# Altium schematic sheet Holiday Aux r1.SchDoc("Holiday Aux r1")
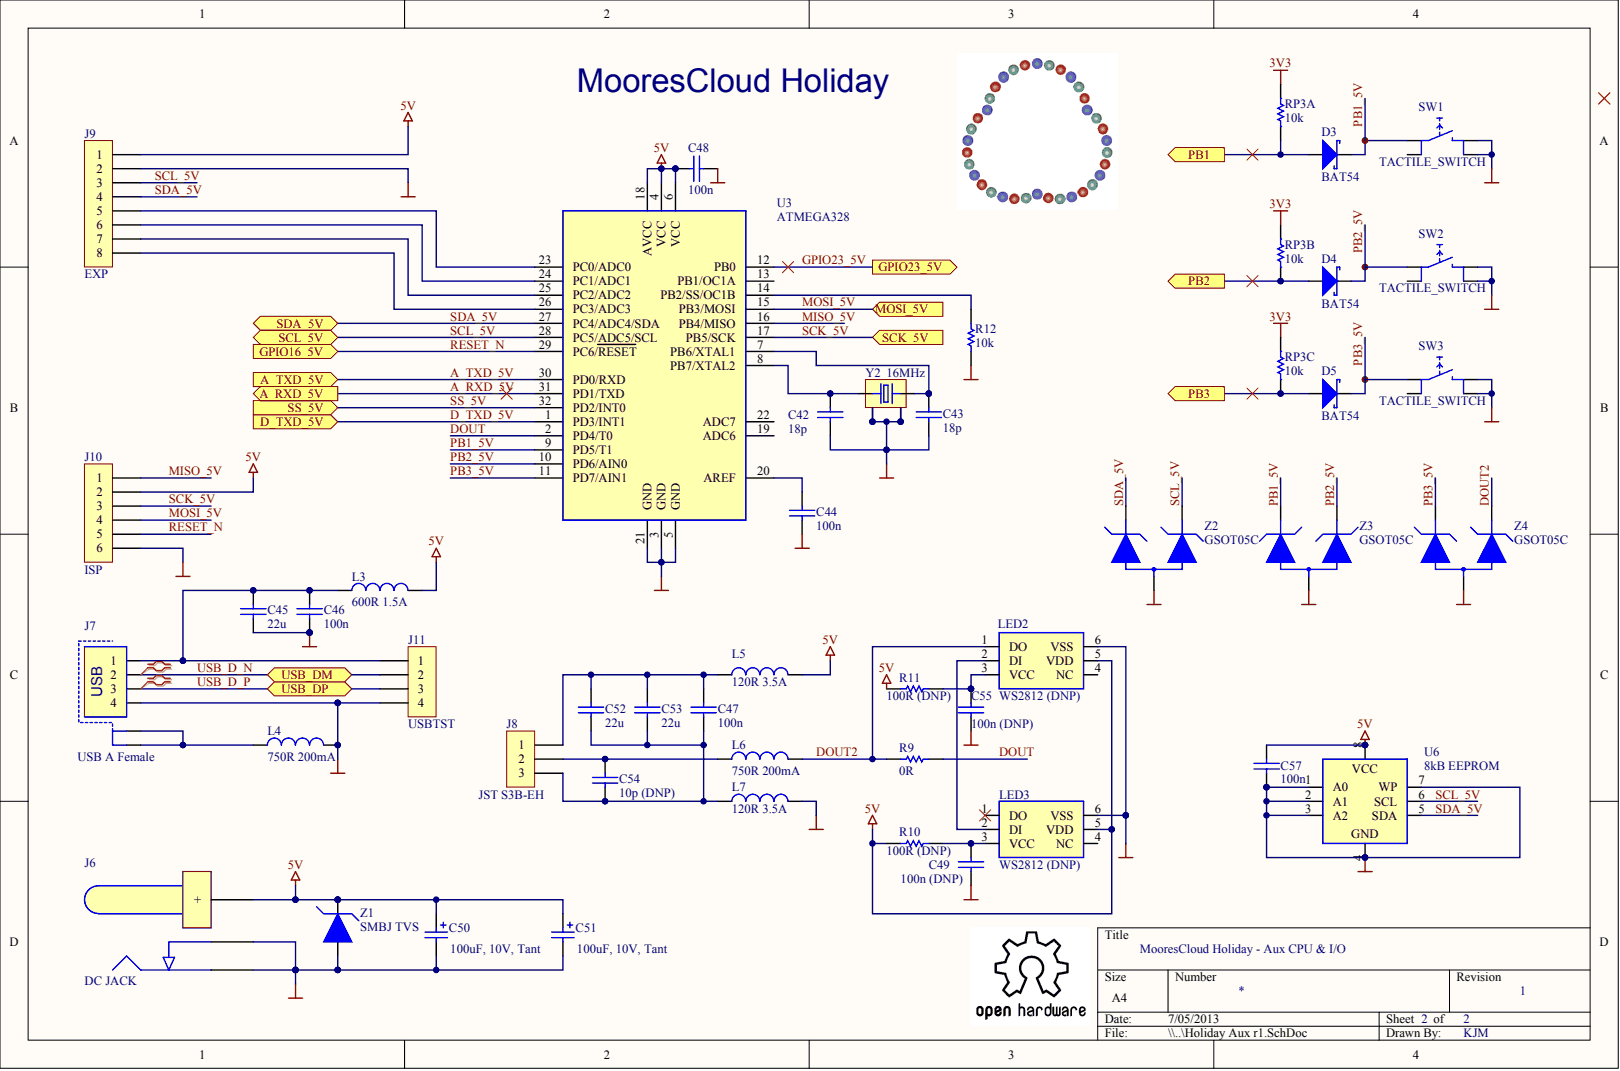
[diagram p0: Holiday Aux r1.SchDoc]

## Parts
C42  18p
C43  18p
C44  100n
C45  22u
C46  100n
C47  100n
C48  100n
C49  100n (DNP)
C50  100uF, 10V, Tant
C51  100uF, 10V, Tant
C52  22u
C53  22u
C54  10p (DNP)
C55  100n (DNP)
C57  100n
D3  BAT54
D4  BAT54
D5  BAT54
J6  DC JACK
J7  USB A Female
J8  JST S3B-EH  1.Manufacturer: JST
J9  EXP
J10  ISP
J11  USBTST
L3  600R 1.5A
L4  750R 200mA
L5  120R 3.5A
L6  750R 200mA
L7  120R 3.5A
LED2  WS2812 (DNP)  2.MfgNo: WS2812
LED3  WS2812 (DNP)  2.MfgNo: WS2812
R9  0R
R10  100R (DNP)
R11  100R (DNP)
R12  10k
RP3  10k  (parts A,B,C)
SW1  TACTILE_SWITCH
SW2  TACTILE_SWITCH
SW3  TACTILE_SWITCH
U3  ATMEGA328
U6  8kB EEPROM
Y2  16MHz
Z1  SMBJ TVS
Z2  GSOT05C
Z3  GSOT05C
Z4  GSOT05C

## Ports
A_RXD_5V, A_TXD_5V, D_TXD_5V, GPIO16_5V, GPIO23_5V, MOSI_5V, PB1, PB2, PB3, SCK_5V, SCL_5V, SDA_5V, SS_5V, USB_DM, USB_DP

## Nets
3V3: RP3.1.2 RP3.2.2 RP3.3.2
5V: C48.1 C50.1 C51.1 C57.1 J6.1 J9.1 J10.2 L3.1 L5.1 LED2.5(VDD) LED3.5(VDD) R10.2 R11.2 U3.4(VCC) U3.6(VCC) U3.18(AVCC) U6.8 Z1.2
GND: C42.2 C43.2 C44.2 C45.2 C46.2 C48.2 C49.1 C50.2 C51.2 C55.1 C57.2 J6.2 J6.3 J7.4 J9.2 J10.6 J11.4 L4.1 L7.1 LED2.6(VSS) LED3.6(VSS) R12.1 SW1.3 SW1.4 SW2.3 SW2.4 SW3.3 SW3.4 U3.3(GND) U3.5(GND) U3.21(GND) U6.1(A0) U6.2(A1) U6.3(A2) U6.4 U6.7(WP) Y2.3 Y2.4 Z1.1 Z2.3 Z3.3 Z4.3
A_RXD_5V: U3.31(PD1/TXD) ; port A_RXD_5V
A_TXD_5V: U3.30(PD0/RXD) ; port A_TXD_5V
D_TXD_5V: U3.1(PD3/INT1) ; port D_TXD_5V
DOUT: R9.2 U3.2(PD4/T0)
DOUT2: L6.1 LED2.1(DO) R9.1 Z4.2
GPIO23_5V: U3.12(PB0) ; port GPIO23_5V
MISO_5V: J10.1 U3.16(PB4/MISO)
MOSI_5V: J10.4 U3.15(PB3/MOSI) ; port MOSI_5V
PB1: D3.1 RP3.1.1 ; port PB1
PB1_5V: D3.2 SW1.1 U3.9(PD5/T1) Z3.2
PB2: D4.1 RP3.2.1 ; port PB2
PB2_5V: D4.2 SW2.1 U3.10(PD6/AIN0) Z3.1
PB3: D5.1 RP3.3.1 ; port PB3
PB3_5V: D5.2 SW3.1 U3.11(PD7/AIN1) Z4.1
RESET_N: J10.5 U3.29(PC6/RESET) ; port GPIO16_5V
SCK_5V: J10.3 U3.17(PB5/SCK) ; port SCK_5V
SCL_5V: J9.3 U3.28(PC5/ADC5/SCL) U6.6(SCL) Z2.2 ; port SCL_5V
SDA_5V: J9.4 U3.27(PC4/ADC4/SDA) U6.5(SDA) Z2.1 ; port SDA_5V
SS_5V: U3.32(PD2/INT0) ; port SS_5V
USB_D_N: J7.2 J11.2 ; port USB_DM
USB_D_P: J7.3 J11.3 ; port USB_DP
NetC42_1: C42.1 U3.8(PB7/XTAL2) Y2.1
NetC43_1: C43.1 U3.7(PB6/XTAL1) Y2.2
NetC44_1: C44.1 U3.20(AREF)
NetC45_1: C45.1 C46.1 J7.1 J11.1 L3.2
NetC47_1: C47.1 C52.2 C53.2 C54.1 J8.3 L7.2
NetC47_2: C47.2 C52.1 C53.1 J8.1 L5.2
NetC49_2: C49.2 LED3.3(VCC) R10.1
NetC54_2: C54.2 J8.2 L6.2
NetC55_2: C55.2 LED2.3(VCC) R11.1
NetJ7_G1: J7.G1 J7.G2 L4.2
NetJ9_5: J9.5 U3.23(PC0/ADC0)
NetJ9_6: J9.6 U3.24(PC1/ADC1)
NetJ9_7: J9.7 U3.25(PC2/ADC2)
NetJ9_8: J9.8 U3.26(PC3/ADC3)
NetLED2_2: LED2.2(DI) LED3.2(DI)
NetR12_2: R12.2 U3.14(PB2/SS/OC1B)

Not connected: LED2 4(NC); LED3 1(DO) 4(NC); SW1 2; SW2 2; SW3 2; U3 13(PB1/OC1A) 19(ADC6) 22(ADC7)

## Library data of the parts
C42 C43 = (no symbol name): 1.Manufacturer: Murata; 2.MfgNo: GRM1885C1H180JA01D; 4.CatNo: 490-1409-2-ND; 9.UnitCost: 0.008; 3.Supplier: Digikey
C44 C46-C49 C55 C57 = (no symbol name): 1.Manufacturer: Yageo; 4.CatNo: 311-1343-2-ND; 2.MfgNo: CC0603ZRY5V9BB104; 9.UnitCost: 0.0034; 3.Supplier: Digikey
C45 C52 C53 = (no symbol name): 1.Manufacturer: TDK; 2.MfgNo: C1608X5R0J226M080AC; 4.CatNo: 445-8028-1-ND; 9.UnitCost: 0.0816; 3.Supplier: Digikey
C50 C51 = (no symbol name): 1.Manufacturer: AVX; 2.MfgNo: TPSD107K010R0100; 4.CatNo: 478-1777-2-ND; 9.UnitCost: 0.33; 3.Supplier: Digikey
C54 = (no symbol name): 1.Manufacturer: Murata; 4.CatNo: 490-1403-1-ND; 2.MfgNo: GRM1885C1H100JA01D; 9.UnitCost: 0.008; 3.Supplier: Digikey
D3-D5 = (no symbol name): 1.Manufacturer: Fairchild; 4.CatNo: BAT54FSTR-ND; 9.UnitCost: 0.027; 2.MfgNo: BAT54; 3.Supplier: Digikey
J6 = (no symbol name): 1.Manufacturer: CUI Inc; 2.MfgNo: PJ-002AH-SMT-TR; 4.CatNo: CP-002AHPJTR-ND; 9.UnitCost: 0.6554; 3.Supplier: Digikey
J7 = (no symbol name): 1.Manufacturer: Assmann; 2.MfgNo: AU-Y1006-R; 4.CatNo: AE9924-ND; 9.UnitCost: 0.430; 3.Supplier: Digikey
J8 = (no symbol name): 4.CatNo: 455-1626-ND; 2.MfgNo: S3B-EH(LF)(SN); 9.UnitCost: 0.07216; 3.Supplier: Digikey
J9-J11 = (no symbol name): 1.Manufacturer: DNP; 9.UnitCost: 0
L3 = (no symbol name): 1.Manufacturer: Laird; 2.MfgNo: MI0805K601R-10; 4.CatNo: 240-2390-2-ND; 9.UnitCost: 0.025; 3.Supplier: DigiKey
L4 L6 = (no symbol name): 1.Manufacturer: Laird; 2.MfgNo: HZ0603B751R-10; 4.CatNo: 240-2547-2-ND; 9.UnitCost: 0.0165; 3.Supplier: DigiKey
L5 L7 = (no symbol name): 1.Manufacturer: Laird; 2.MfgNo: HI0805O121R-10; 4.CatNo: 240-2393-2-ND; 9.UnitCost: 0.0234; 3.Supplier: DigiKey
LED2 LED3 = (no symbol name): 1.Manufacturer: WorldSemi; 4.CatNo: sc-WS2811-5050; 9.UnitCost: 0.15; 3.Supplier: Shenzhen Scott Electronics
R9 = (no symbol name): 1.Manufacturer: Yageo; 4.CatNo: 311-0.0GRTR-ND; 2.MfgNo: RC0603JR-070RL; 9.UnitCost: 0.00131; 3.Supplier: Digikey
R10 R11 = (no symbol name): 1.Manufacturer: Yageo; 4.CatNo: 311-100GRTR-ND; 2.MfgNo: RC0603JR-07100RL; 9.UnitCost: 0.00131; 3.Supplier: Digikey
R12 = (no symbol name): 1.Manufacturer: Yageo; 9.UnitCost: 0.0017; 4.CatNo: 311-10.0KHRTR-ND; 2.MfgNo: RC0603FR-0710KL; 3.Supplier: Digikey
RP3 = (no symbol name): 1.Manufacturer: Vishay Dale; 2.MfgNo: CRA06S08310K0JTA; 4.CatNo: CRA6S810KTR-ND; 9.UnitCost: 0.009; 3.Supplier: Digikey
SW1-SW3 = (no symbol name): 1.Manufacturer: TE Connectivity; 4.CatNo: 450-1759-2-ND; 2.MfgNo: FSM4JSMATR; 9.UnitCost: 0.1543; 3.Supplier: Digikey
U3 = (no symbol name): 1.Manufacturer: Atmel; 4.CatNo: ATMEGA328-AURTR-ND; 2.MfgNo: ATMEGA328-AUR; 9.UnitCost: 1.608; 3.Supplier: Digikey
U6 = (no symbol name): 1.Manufacturer: On Semi; 4.CatNo: CAT24C64WI-GT3TR-ND; 2.MfgNo: CAT24C64WI-GT3; 9.UnitCost: 0.148; 3.Supplier: Digikey
Y2 = (no symbol name): 1.Manufacturer: Abracon; 2.MfgNo: ABMM2-16.000MHZ-E2-T; 4.CatNo: 535-9634-2-ND; 9.UnitCost: 0.48; 3.Supplier: Digikey
Z1 = (no symbol name): 1.Manufacturer: Bourns; 4.CatNo: SMBJ6.0ABTR-ND; 2.MfgNo: SMBJ6.0A; 9.UnitCost: 0.10; 3.Supplier: Digikey
Z2-Z4 = (no symbol name): 1.Manufacturer: Vishay Semi; 2.MfgNo: GSOT05C-GS08; 4.CatNo: 751-1415-2-ND; 9.UnitCost: 0.085; 3.Supplier: Digikey
